# Altium schematic sheet Raspberry Pi Fan.SchDoc
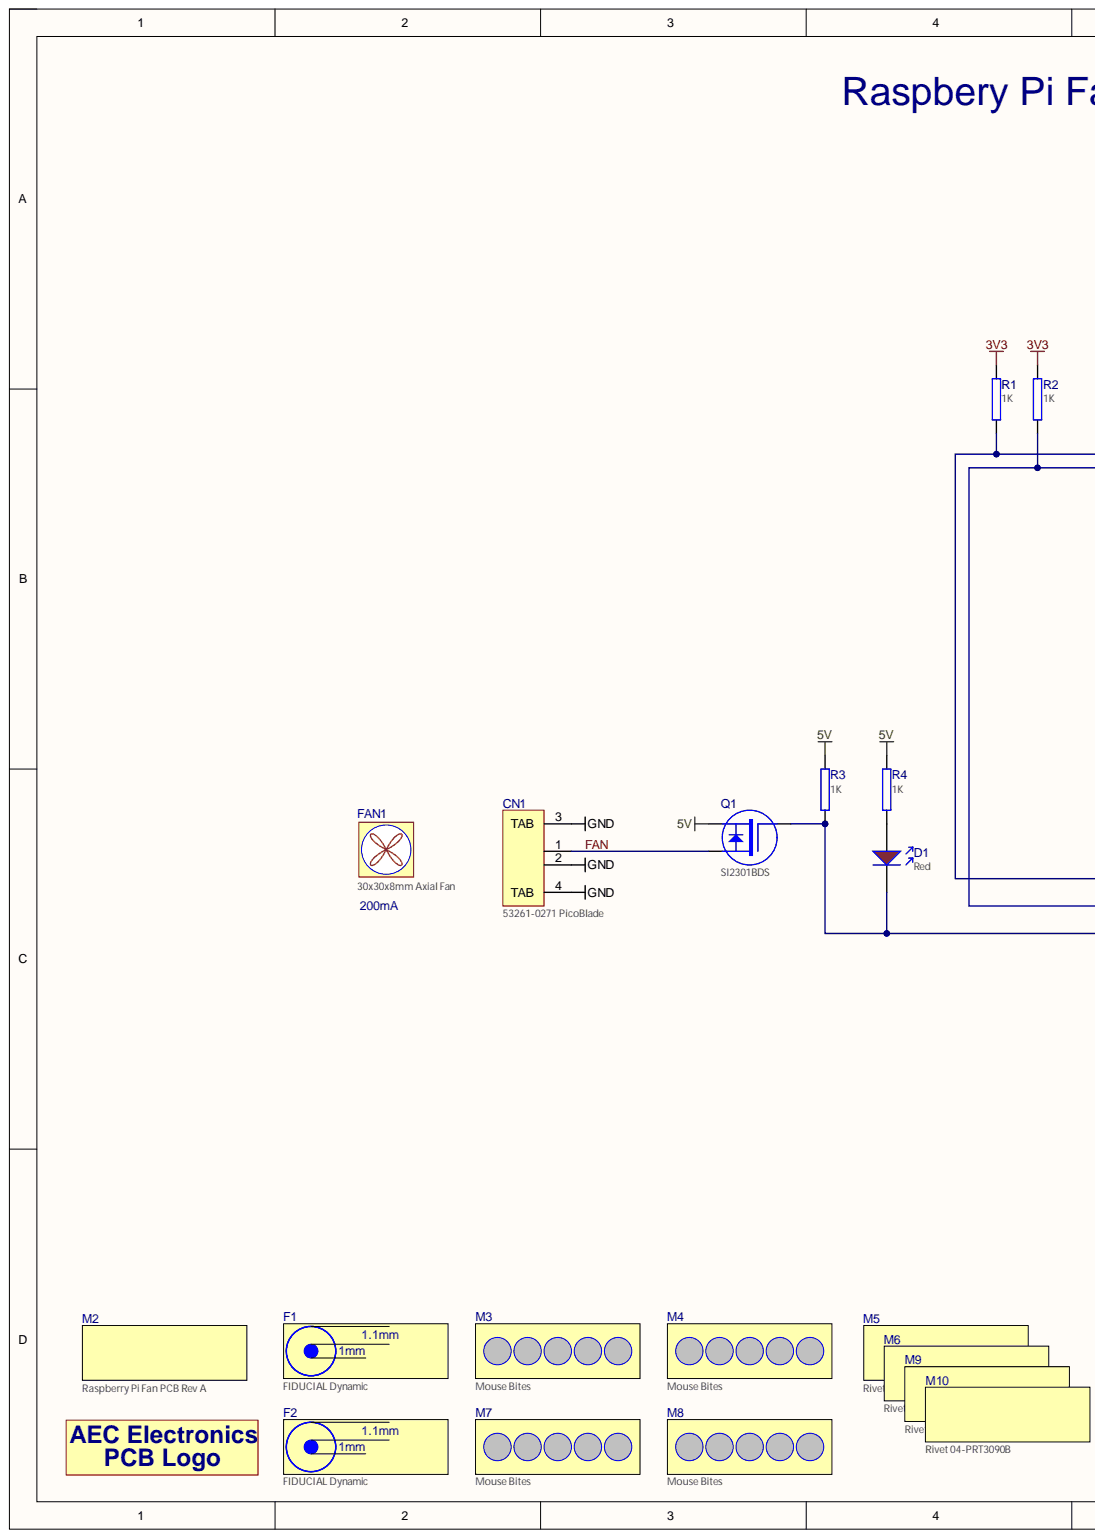
[diagram p0: Raspberry Pi Fan.SchDoc, left]
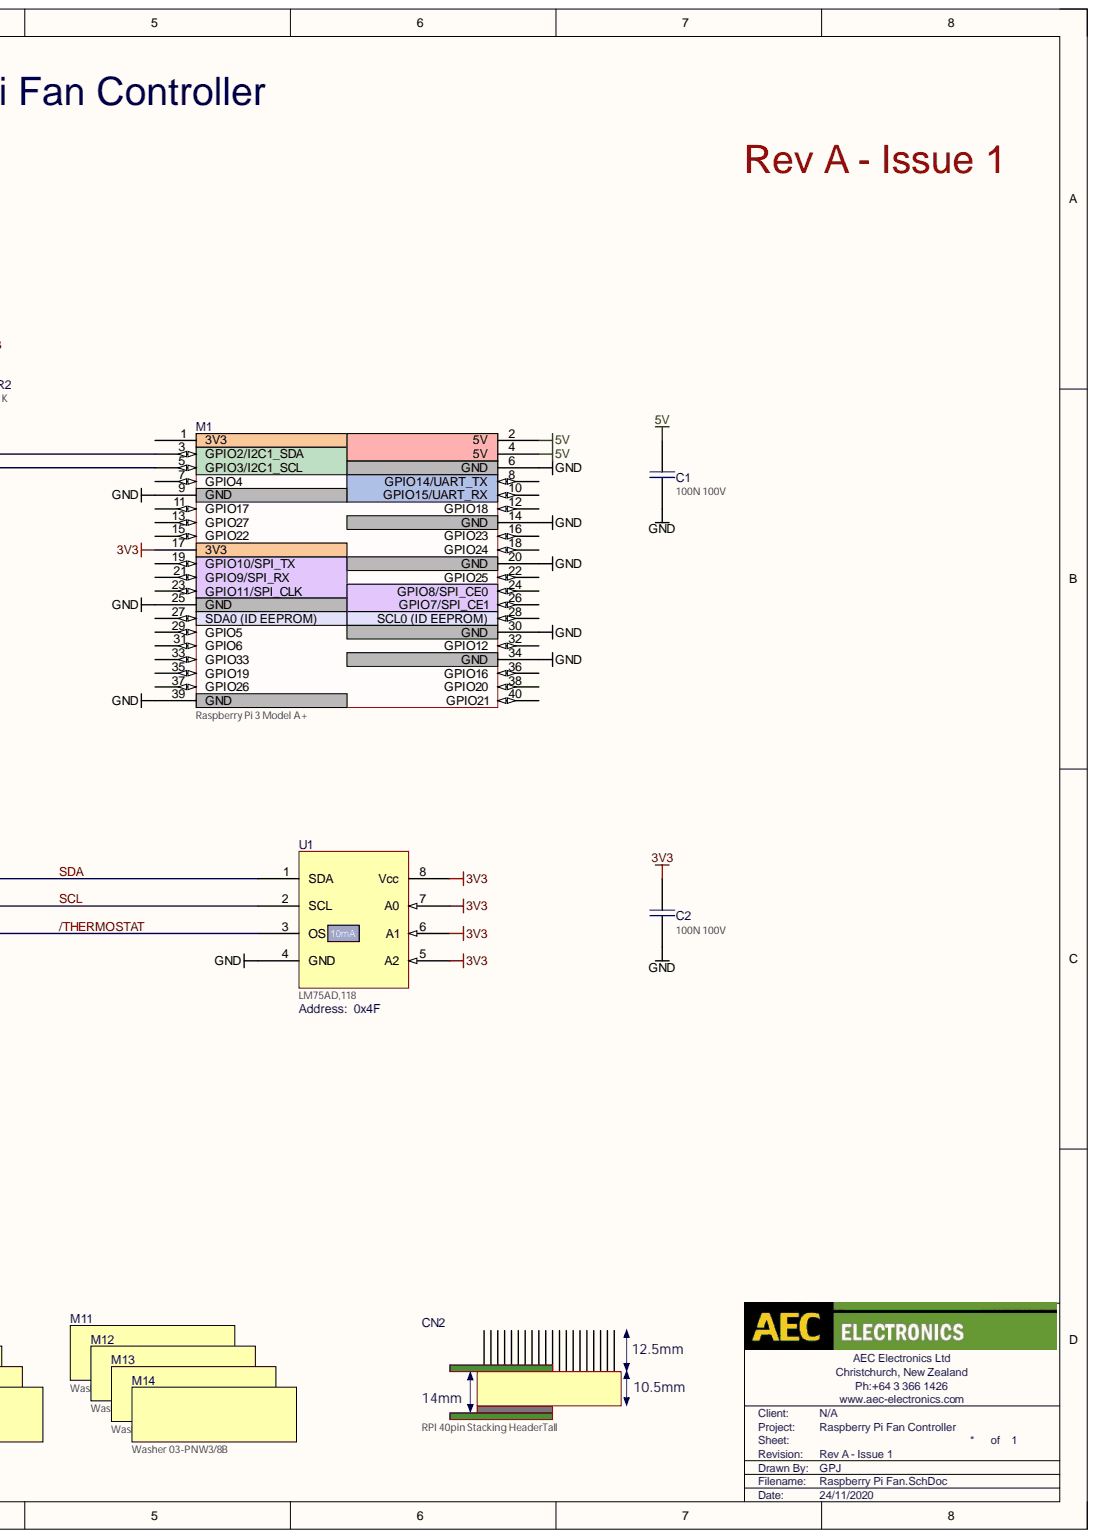
[diagram p1: Raspberry Pi Fan.SchDoc, right]

## Parts
C1  100N 100V  [0603]
C2  100N 100V  [0603]
CN1  53261-0271 PicoBlade  [Molex 53261-0271]
CN2  RPI 40pin Stacking HeaderTall  (mechanical)
D1  Red  [0603 - LED - RED]
F1  FIDUCIAL Dynamic  [FIDUCIAL - Dynamic]  (no BOM)
F2  FIDUCIAL Dynamic  [FIDUCIAL - Dynamic]  (no BOM)
FAN1  30x30x8mm Axial Fan  [Fan 30x30x8]
Logo1  LOGO AEC Electronics  [Logo AEC Electronics Small]  (value not drawn)  (no BOM)
M1  Raspberry Pi 3 Model A+  [RASPBERRY PI HAT]  (no BOM)
M2  Raspberry Pi Fan PCB Rev A  (mechanical)
M3  Mouse Bites  [MOUSE BITES]  (no BOM)
M4  Mouse Bites  [MOUSE BITES]  (no BOM)
M5  Rivet 04-PRT3090B  HiQ Part Number: 04-PRT3090B  (mechanical)
M6  Rivet 04-PRT3090B  HiQ Part Number: 04-PRT3090B  (mechanical)
M7  Mouse Bites  [MOUSE BITES]  (no BOM)
M8  Mouse Bites  [MOUSE BITES]  (no BOM)
M9  Rivet 04-PRT3090B  HiQ Part Number: 04-PRT3090B  (mechanical)
M10  Rivet 04-PRT3090B  HiQ Part Number: 04-PRT3090B  (mechanical)
M11  Washer 03-PNW3/8B  HiQ Part Number: 03-PNW3/8B  (mechanical)
M12  Washer 03-PNW3/8B  HiQ Part Number: 03-PNW3/8B  (mechanical)
M13  Washer 03-PNW3/8B  HiQ Part Number: 03-PNW3/8B  (mechanical)
M14  Washer 03-PNW3/8B  HiQ Part Number: 03-PNW3/8B  (mechanical)
Q1  SI2301BDS  [SOT-23]
R1  1K  [0603]
R2  1K  [0603]
R3  1K  [0603]
R4  1K  [0603]
U1  LM75AD,118  [SOIC127P600X175-8N]

## Nets
3V3: C2.1 M1.17(3V3) R1.2 R2.2 U1.5(A2) U1.6(A1) U1.7(A0) U1.8(Vcc)
5V: C1.1 M1.2(5V) M1.4(5V) Q1.2 R3.2 R4.2
GND: C1.2 C2.2 CN1.2 CN1.3(TAB) CN1.4(TAB) M1.6(GND) M1.9(GND) M1.14(GND) M1.20(GND) M1.25(GND) M1.30(GND) M1.34(GND) M1.39(GND) U1.4(GND)
/THERMOSTAT: D1.2 Q1.1 R3.1 U1.3(OS)
FAN: CN1.1 Q1.3
SCL: M1.5(GPIO3/I2C1_SCL) R2.1 U1.2(SCL)
SDA: M1.3(GPIO2/I2C1_SDA) R1.1 U1.1(SDA)
NetD1_1: D1.1 R4.1

Not connected: M1 1(3V3) 7(GPIO4) 8(GPIO14/UART_TX) 10(GPIO15/UART_RX) 11(GPIO17) 12(GPIO18) 13(GPIO27) 15(GPIO22) 16(GPIO23) 18(GPIO24) 19(GPIO10/SPI_TX) 21(GPIO9/SPI_RX) 22(GPIO25) 23(GPIO11/SPI_CLK) 24(GPIO8/SPI_CE0) 26(GPIO7/SPI_CE1) 27(SDA0_(ID_EEPROM)) 28(SCL0_(ID_EEPROM)) 29(GPIO5) 31(GPIO6) 32(GPIO12) 33(GPIO33) 35(GPIO19) 36(GPIO16) 37(GPIO26) 38(GPIO20) 40(GPIO21)

## Library data of the parts
C1 C2 = CAP 100N 100V 0603: Capacitor 100nF 100V X7R 10% 0603; Manufacturer: Yageo; Manufacturer Part Number: CC0603KRX7R0BB104; Component Kind: Standard; Supplier 1: Digi-Key; Supplier Part Number 1: 311-1523-1-ND; Library Name: AEC New.IntLib
CN1 = CON MOLEX SMD 53261-0271 R/A: Connector 2way Molex 53261 SMT Header RA; Manufacturer Part Number: 0532610271; Component Kind: Standard; Digi-Key Part Number: WM7620CT-ND; Manufacturer: Molex; Supplier 1: Digi-Key; Supplier Part Number 1: WM7620CT-ND; Library Name: AEC New.IntLib
CN2 = CON 40-WAY RPI STACKING HEADER TALL: Connector 40way RPI Stacking Header Tall; Other Supplier: Jaycar; Other Supplier Part Number: HM3228; Library Name: AEC New.IntLib
D1 = LED RED 0603: LED RED APT1608SRCPRV 30mcd 0603; Manufacturer: Kingbright; Component Kind: Standard; Digi-Key Part Number: 754-1122-6-ND; Manufacturer Part Number: APT1608SRCPRV; Supplier 1: Digi-Key; Supplier Part Number 1: 754-1122-6-ND; Library Name: AEC New.IntLib
F1 F2 = FIDUCIAL Dynamic: 1mm Fiducial with 1.05mm Cclearance; Library Name: AEC New.IntLib
FAN1 = Fan 30x30x8: 30x30x8mm Fan 5VDC 200mA. Pico Blade Connector; Manufacturer: Adafruit; Manufacturer Part Number: 4468; Component Kind: Standard; Supplier 1: Digi-Key; Supplier Part Number 1: 1528-4468-ND; Library Name: AEC New.IntLib
M1 = MODULE RASPBERRY PI 3 MODEL A+: Manufacturer: Raspberry-Pi; Manufacturer Part Number: RPI3-MODAP; Description: Module Raspberry Pi 3 Model A+; element 14 Part Number: 2946269; Library Name: AEC New.IntLib
M2 M5 M6 M9-M14 = MISC PART: Misc Part - Use Sparingly!!!; Library Name: AEC New.IntLib
M3 M4 M7 M8 = Mouse Bites: 5x 0.8mm holes for panel break out tabs; Library Name: AEC New.IntLib
Q1 = TRANSISTOR FET SI2301BDS: Transistor FET SI2301BDS 20V 2.4A P-CHANNEL SOT23; Component Kind: Standard; Supplier 1: Digi-Key; Supplier Part Number 1: SI2301BDS-T1-E3CT-ND; Library Name: AEC New.IntLib
R1-R4 = RES 1K 0603: Resistor 1k 1% 0603; Manufacturer: Yaego; Manufacturer Part Number: RC0603FR-071KL; Component Kind: Standard; Supplier 1: Digi-Key; Supplier Part Number 1: 311-1.00KHRCT-ND; Library Name: AEC New.IntLib
U1 = IC LM75AD,118: IC LM75A I2C Temperature Sensor SO8; Manufacturer: NXP; Component Kind: Standard; Digi-Key Part Number: 568-10295-1-ND; element 14 Part Number: 2776067; Manufacturer Part Number: LM75AD,118; Mouser Part Number: 771-LM75AD-T; Supplier 1: Digi-Key; Supplier Part Number 1: 568-10295-1-ND; Library Name: AEC New.SchLib
Logo1 = LOGO AEC Electronics: Library Name: AEC New.IntLib
